# Altium schematic sheet [04] - USER IO AND LEDs.SchDoc("[04] - USER IO AND LEDs")
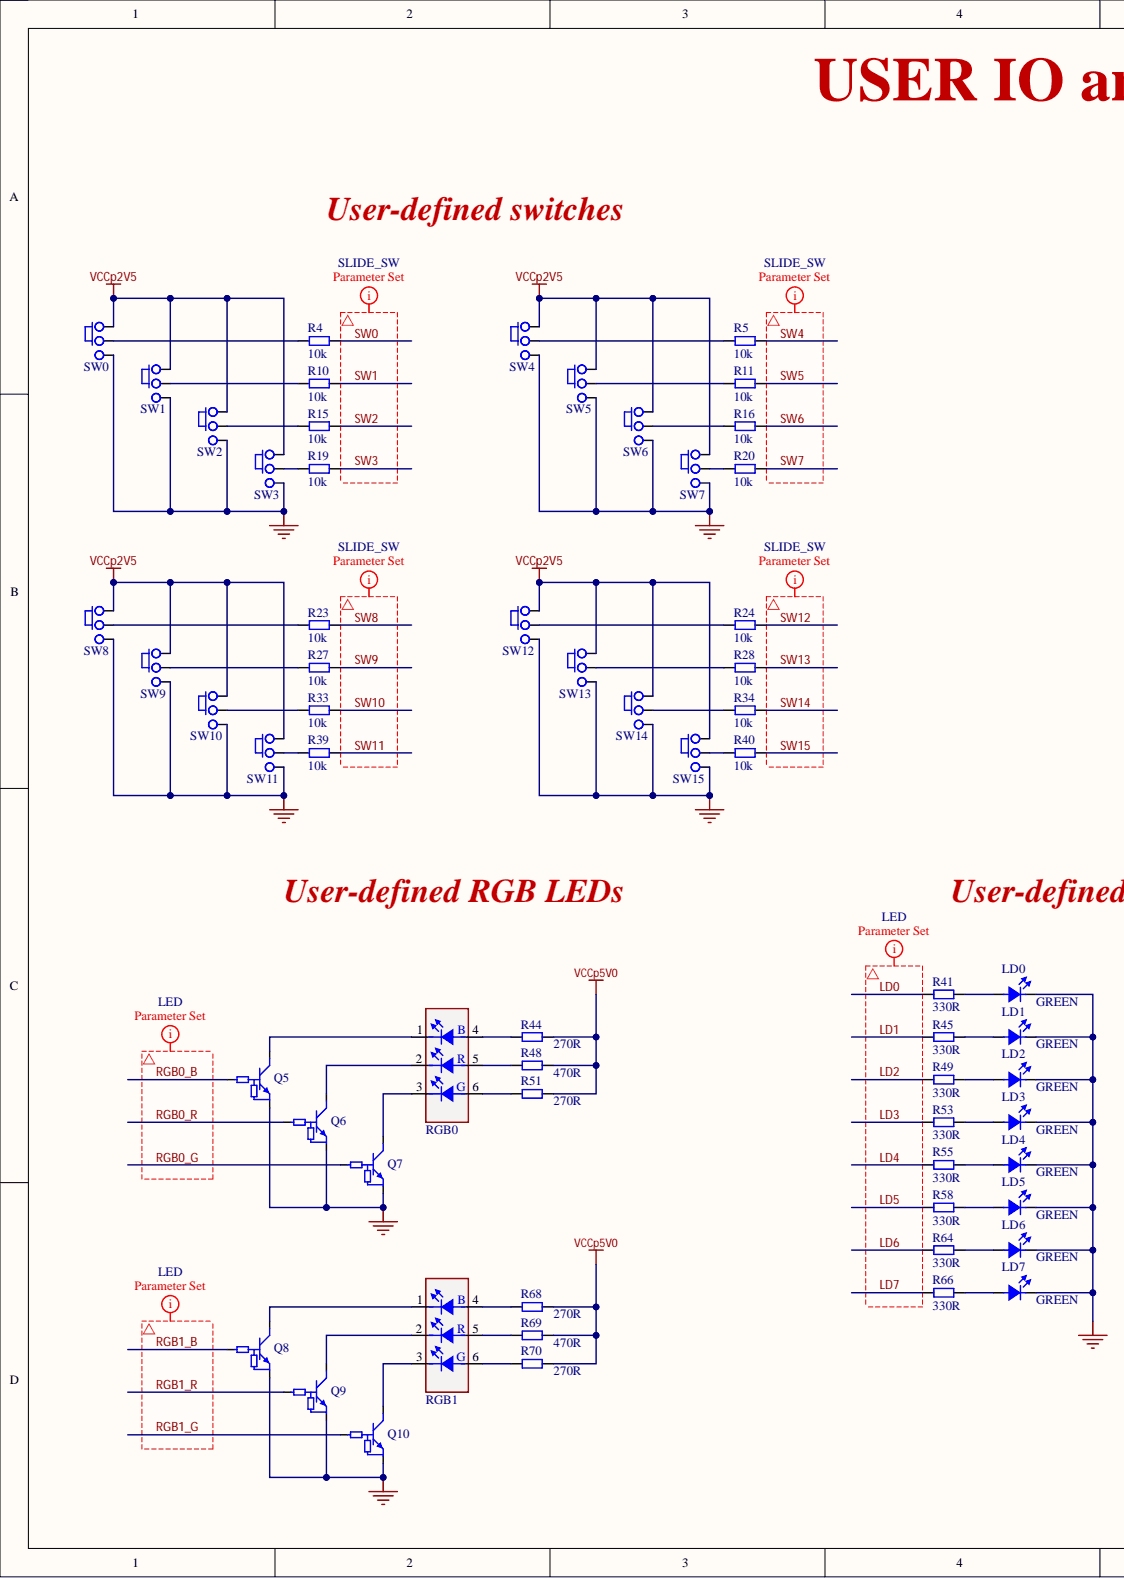
[diagram p0: [04] - USER IO AND LEDs.SchDoc, left]
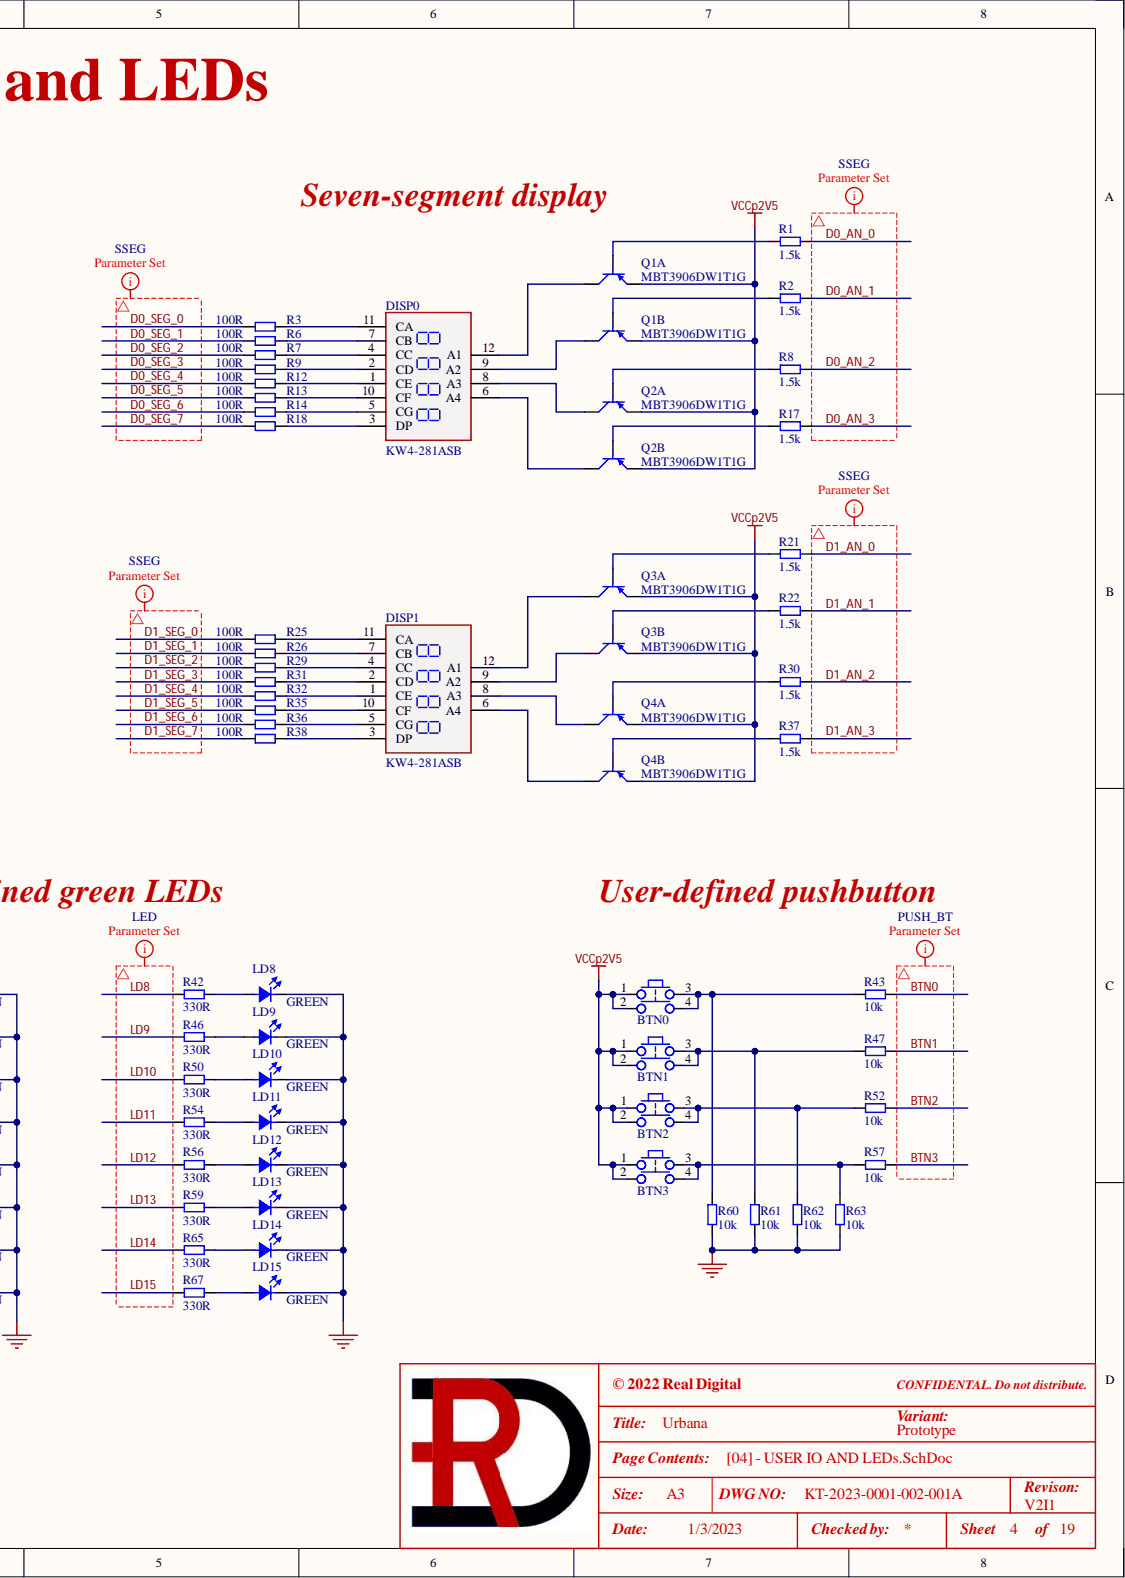
[diagram p1: [04] - USER IO AND LEDs.SchDoc, right]

## Parts
BTN0  PTA-142  [PTA-142]  (value not drawn)
BTN1  PTA-142  [PTA-142]  (value not drawn)
BTN2  PTA-142  [PTA-142]  (value not drawn)
BTN3  PTA-142  [PTA-142]  (value not drawn)
DISP0  KW4-281ASB  [KW4-281ASB]
DISP1  KW4-281ASB  [KW4-281ASB]
LD0  GREEN  [LD0603_Green]
LD1  GREEN  [LD0603_Green]
LD2  GREEN  [LD0603_Green]
LD3  GREEN  [LD0603_Green]
LD4  GREEN  [LD0603_Green]
LD5  GREEN  [LD0603_Green]
LD6  GREEN  [LD0603_Green]
LD7  GREEN  [LD0603_Green]
LD8  GREEN  [LD0603_Green]
LD9  GREEN  [LD0603_Green]
LD10  GREEN  [LD0603_Green]
LD11  GREEN  [LD0603_Green]
LD12  GREEN  [LD0603_Green]
LD13  GREEN  [LD0603_Green]
LD14  GREEN  [LD0603_Green]
LD15  GREEN  [LD0603_Green]
Q1  MBT3906DW1T1G  [SOT-363]  (parts A,B)
Q2  MBT3906DW1T1G  [SOT-363]  (parts A,B)
Q3  MBT3906DW1T1G  [SOT-363]  (parts A,B)
Q4  MBT3906DW1T1G  [SOT-363]  (parts A,B)
Q5  DTC043ZEBTL  [SC89-EMT3F]  (value not drawn)
Q6  DTC043ZEBTL  [SC89-EMT3F]  (value not drawn)
Q7  DTC043ZEBTL  [SC89-EMT3F]  (value not drawn)
Q8  DTC043ZEBTL  [SC89-EMT3F]  (value not drawn)
Q9  DTC043ZEBTL  [SC89-EMT3F]  (value not drawn)
Q10  DTC043ZEBTL  [SC89-EMT3F]  (value not drawn)
R1  1.5k  [R0402]
R2  1.5k  [R0402]
R3  100R  [R0402]
R4  10k  [R0402]
R5  10k  [R0402]
R6  100R  [R0402]
R7  100R  [R0402]
R8  1.5k  [R0402]
R9  100R  [R0402]
R10  10k  [R0402]
R11  10k  [R0402]
R12  100R  [R0402]
R13  100R  [R0402]
R14  100R  [R0402]
R15  10k  [R0402]
R16  10k  [R0402]
R17  1.5k  [R0402]
R18  100R  [R0402]
R19  10k  [R0402]
R20  10k  [R0402]
R21  1.5k  [R0402]
R22  1.5k  [R0402]
R23  10k  [R0402]
R24  10k  [R0402]
R25  100R  [R0402]
R26  100R  [R0402]
R27  10k  [R0402]
R28  10k  [R0402]
R29  100R  [R0402]
R30  1.5k  [R0402]
R31  100R  [R0402]
R32  100R  [R0402]
R33  10k  [R0402]
R34  10k  [R0402]
R35  100R  [R0402]
R36  100R  [R0402]
R37  1.5k  [R0402]
R38  100R  [R0402]
R39  10k  [R0402]
R40  10k  [R0402]
R41  330R  [R0402]
R42  330R  [R0402]
R43  10k  [R0402]
R44  270R  [R0402]
R45  330R  [R0402]
R46  330R  [R0402]
R47  10k  [R0402]
R48  470R  [R0402]
R49  330R  [R0402]
R50  330R  [R0402]
R51  270R  [R0402]
R52  10k  [R0402]
R53  330R  [R0402]
R54  330R  [R0402]
R55  330R  [R0402]
R56  330R  [R0402]
R57  10k  [R0402]
R58  330R  [R0402]
R59  330R  [R0402]
R60  10k  [R0402]
R61  10k  [R0402]
R62  10k  [R0402]
R63  10k  [R0402]
R64  330R  [R0402]
R65  330R  [R0402]
R66  330R  [R0402]
R67  330R  [R0402]
R68  270R  [R0402]
R69  470R  [R0402]
R70  270R  [R0402]
RGB0  VS NRD8  [LD_RGB_NRD8]  (value not drawn)
RGB1  VS NRD8  [LD_RGB_NRD8]  (value not drawn)
SW0  Switch  [SW-10SP001]  (value not drawn)
SW1  Switch  [SW-10SP001]  (value not drawn)
SW2  Switch  [SW-10SP001]  (value not drawn)
SW3  Switch  [SW-10SP001]  (value not drawn)
SW4  Switch  [SW-10SP001]  (value not drawn)
SW5  Switch  [SW-10SP001]  (value not drawn)
SW6  Switch  [SW-10SP001]  (value not drawn)
SW7  Switch  [SW-10SP001]  (value not drawn)
SW8  Switch  [SW-10SP001]  (value not drawn)
SW9  Switch  [SW-10SP001]  (value not drawn)
SW10  Switch  [SW-10SP001]  (value not drawn)
SW11  Switch  [SW-10SP001]  (value not drawn)
SW12  Switch  [SW-10SP001]  (value not drawn)
SW13  Switch  [SW-10SP001]  (value not drawn)
SW14  Switch  [SW-10SP001]  (value not drawn)
SW15  Switch  [SW-10SP001]  (value not drawn)

## Nets
GND: LD0.K LD1.K LD2.K LD3.K LD4.K LD5.K LD6.K LD7.K LD8.K LD9.K LD10.K LD11.K LD12.K LD13.K LD14.K LD15.K Q5.GND Q6.GND Q7.GND Q8.GND Q9.GND Q10.GND R60.1 R61.1 R62.1 R63.1 SW0.3 SW1.3 SW2.3 SW3.3 SW4.3 SW5.3 SW6.3 SW7.3 SW8.3 SW9.3 SW10.3 SW11.3 SW12.3 SW13.3 SW14.3 SW15.3
VCCp2V5: BTN0.1 BTN0.2 BTN1.1 BTN1.2 BTN2.1 BTN2.2 BTN3.1 BTN3.2 Q1.1 Q1.4 Q2.1 Q2.4 Q3.1 Q3.4 Q4.1 Q4.4 SW0.1 SW1.1 SW2.1 SW3.1 SW4.1 SW5.1 SW6.1 SW7.1 SW8.1 SW9.1 SW10.1 SW11.1 SW12.1 SW13.1 SW14.1 SW15.1
VCCp5V0: R44.2 R48.2 R51.2 R68.2 R69.2 R70.2
BTN0: R43.2
BTN1: R47.2
BTN2: R52.2
BTN3: R57.2
D0_AN_0: R1.2
D0_AN_1: R2.2
D0_AN_2: R8.2
D0_AN_3: R17.2
D0_SEG_0: R3.1
D0_SEG_1: R6.1
D0_SEG_2: R7.1
D0_SEG_3: R9.1
D0_SEG_4: R12.1
D0_SEG_5: R13.1
D0_SEG_6: R14.1
D0_SEG_7: R18.1
D1_AN_0: R21.2
D1_AN_1: R22.2
D1_AN_2: R30.2
D1_AN_3: R37.2
D1_SEG_0: R25.1
D1_SEG_1: R26.1
D1_SEG_2: R29.1
D1_SEG_3: R31.1
D1_SEG_4: R32.1
D1_SEG_5: R35.1
D1_SEG_6: R36.1
D1_SEG_7: R38.1
LD0: R41.1
LD1: R45.1
LD2: R49.1
LD3: R53.1
LD4: R55.1
LD5: R58.1
LD6: R64.1
LD7: R66.1
LD8: R42.1
LD9: R46.1
LD10: R50.1
LD11: R54.1
LD12: R56.1
LD13: R59.1
LD14: R65.1
LD15: R67.1
RGB0_B: Q5.IN
RGB0_G: Q7.IN
RGB0_R: Q6.IN
RGB1_B: Q8.IN
RGB1_G: Q10.IN
RGB1_R: Q9.IN
SW0: R4.2
SW1: R10.2
SW2: R15.2
SW3: R19.2
SW4: R5.2
SW5: R11.2
SW6: R16.2
SW7: R20.2
SW8: R23.2
SW9: R27.2
SW10: R33.2
SW11: R39.2
SW12: R24.2
SW13: R28.2
SW14: R34.2
SW15: R40.2
NetBTN0_3: BTN0.3 BTN0.4 R43.1 R60.2
NetBTN1_3: BTN1.3 BTN1.4 R47.1 R61.2
NetBTN2_3: BTN2.3 BTN2.4 R52.1 R62.2
NetBTN3_3: BTN3.3 BTN3.4 R57.1 R63.2
NetDISP0_1: DISP0.1(CE) R12.2
NetDISP0_2: DISP0.2(CD) R9.2
NetDISP0_3: DISP0.3(DP) R18.2
NetDISP0_4: DISP0.4(CC) R7.2
NetDISP0_5: DISP0.5(CG) R14.2
NetDISP0_6: DISP0.6(A4) Q2.6
NetDISP0_7: DISP0.7(CB) R6.2
NetDISP0_8: DISP0.8(A3) Q2.3
NetDISP0_9: DISP0.9(A2) Q1.6
NetDISP0_10: DISP0.10(CF) R13.2
NetDISP0_11: DISP0.11(CA) R3.2
NetDISP0_12: DISP0.12(A1) Q1.3
NetDISP1_1: DISP1.1(CE) R32.2
NetDISP1_2: DISP1.2(CD) R31.2
NetDISP1_3: DISP1.3(DP) R38.2
NetDISP1_4: DISP1.4(CC) R29.2
NetDISP1_5: DISP1.5(CG) R36.2
NetDISP1_6: DISP1.6(A4) Q4.6
NetDISP1_7: DISP1.7(CB) R26.2
NetDISP1_8: DISP1.8(A3) Q4.3
NetDISP1_9: DISP1.9(A2) Q3.6
NetDISP1_10: DISP1.10(CF) R35.2
NetDISP1_11: DISP1.11(CA) R25.2
NetDISP1_12: DISP1.12(A1) Q3.3
NetLD0_A: LD0.A R41.2
NetLD1_A: LD1.A R45.2
NetLD2_A: LD2.A R49.2
NetLD3_A: LD3.A R53.2
NetLD4_A: LD4.A R55.2
NetLD5_A: LD5.A R58.2
NetLD6_A: LD6.A R64.2
NetLD7_A: LD7.A R66.2
NetLD8_A: LD8.A R42.2
NetLD9_A: LD9.A R46.2
NetLD10_A: LD10.A R50.2
NetLD11_A: LD11.A R54.2
NetLD12_A: LD12.A R56.2
NetLD13_A: LD13.A R59.2
NetLD14_A: LD14.A R65.2
NetLD15_A: LD15.A R67.2
NetQ1_2: Q1.2 R2.1
NetQ1_5: Q1.5 R1.1
NetQ2_2: Q2.2 R17.1
NetQ2_5: Q2.5 R8.1
NetQ3_2: Q3.2 R22.1
NetQ3_5: Q3.5 R21.1
NetQ4_2: Q4.2 R37.1
NetQ4_5: Q4.5 R30.1
NetQ5_OUT: Q5.OUT RGB0.1
NetQ6_OUT: Q6.OUT RGB0.2
NetQ7_OUT: Q7.OUT RGB0.3
NetQ8_OUT: Q8.OUT RGB1.1
NetQ9_OUT: Q9.OUT RGB1.2
NetQ10_OUT: Q10.OUT RGB1.3
NetR4_1: R4.1 SW0.2
NetR5_1: R5.1 SW4.2
NetR10_1: R10.1 SW1.2
NetR11_1: R11.1 SW5.2
NetR15_1: R15.1 SW2.2
NetR16_1: R16.1 SW6.2
NetR19_1: R19.1 SW3.2
NetR20_1: R20.1 SW7.2
NetR23_1: R23.1 SW8.2
NetR24_1: R24.1 SW12.2
NetR27_1: R27.1 SW9.2
NetR28_1: R28.1 SW13.2
NetR33_1: R33.1 SW10.2
NetR34_1: R34.1 SW14.2
NetR39_1: R39.1 SW11.2
NetR40_1: R40.1 SW15.2
NetR44_1: R44.1 RGB0.4
NetR48_1: R48.1 RGB0.5
NetR51_1: R51.1 RGB0.6
NetR68_1: R68.1 RGB1.4
NetR69_1: R69.1 RGB1.5
NetR70_1: R70.1 RGB1.6

## Library data of the parts
BTN0-BTN3 = Tact Switch: SWITCH TACTILE SPST-NO, 4.50mm x 4.50mm; Manufacturer 1: Pacer Technology Co., Ltd; Manufacturer 2 Part Number: TSXH-2GL; .Checked_PCB: RV; C P/N: 153-0059; LoadStatus: Load; Category: Tactile Switches; Component Kind: Standard; Library Name: KT-RealDigital.IntLib; Manufacturer 1 Part Number: PTA-142; .Checked_SCH: RV; .Creator: RV
DISP0 DISP1 = KW4-281ASB: 4 Digit, 12 Pins, Common Anode , 7 Segment Display , Red; Manufacturer 1: Luckylight Electronics Co., Ltd; Manufacturer 2 Part Number: 2841-BSR; .Checked_PCB: AC; .Creator: RV; C P/N: 144-0013; LoadStatus: Load; Category: Display; Component Kind: Standard; Library Name: KT-RealDigital.IntLib; Manufacturer 1 Part Number: KW4-281ASB; Manufacturer 2: Houkem; .Checked_SCH: AC
LD0-LD15 = LED_GREEN: LED GREEN 0603; Manufacturer 1: Everlight Electronics CO., LTD; Manufacturer 1 Part Number: 19-21SYGC/S530-E4/TR8; .Checked_PCB: AC; .Creator: RV; C P/N: 121-0006; LoadStatus: Load; Category: Led; Component Kind: Standard; Library Name: KT-RealDigital.IntLib; .Checked_SCH: AC
Q1-Q4 = MBT3906DW1T1G: TRANS 2PNP 40V 0.2A SOT363; Manufacturer 1: ON Semiconductor; .Checked_PCB: AC; .Creator: RV; C P/N: 121-0032; LoadStatus: Load; Category: Transistors; Component Kind: Standard; Library Name: KT-RealDigital.IntLib; Manufacturer 1 Part Number: MBT3906DW1T1G; .Checked_SCH: AC
Q5-Q10 = DTC043ZEBTL: TRANS PREBIAS NPN 50V EMT3F; Manufacturer 1: Rohm Semiconductor; .Checked_PCB: RV; LoadStatus: Load; Category: BJTs; Component Kind: Standard; Library Name: KT-RealDigital.IntLib; Manufacturer 1 Part Number: DTC043ZEBTL; .Checked_SCH: RV; .Creator: RV
R1 R2 R8 R17 R21 R22 R30 R37 = RC0402FR-071K5L: RES 1.5K OHM 1% 1/16W 0402; Manufacturer 1 Part Number: YAGEO; .Checked_PCB: RV; LoadStatus: Load; Category: Resistors; Component Kind: Standard; Library Name: KT-RealDigital.IntLib; Manufacturer 1: RC0402FR-071K5L; Tolerance: 1%; .Checked_SCH: RV; .Creator: RV
R3 R6 R7 R9 R12-R14 R18 R25 R26 R29 R31 R32 R35 R36 R38 = RC0402JR-07100RL: RES SMD 100 OHM 5% 1/16W 0402; Manufacturer 1: Yageo; .Checked_PCB: AC; .Creator: RV; C P/N: 101-0035; LoadStatus: Load; Category: Resistors; Component Kind: Standard; Library Name: KT-RealDigital.IntLib; Manufacturer 1 Part Number: RC0402JR-07100RL; Tolerance: 5%; .Checked_SCH: AC
R4 R5 R10 R11 R15 R16 R19 R20 R23 R24 R27 R28 R33 R34 R39 R40 R43 R47 R52 R57 R60-R63 = RC0402JR-0710KL: RES SMD 10K OHM 5% 1/16W 0402; Manufacturer 1: Yageo; .Checked_PCB: AC; .Creator: RV; C P/N: 101-0047; LoadStatus: Load; Category: Resistors; Component Kind: Standard; Library Name: KT-RealDigital.IntLib; Manufacturer 1 Part Number: RC0402JR-0710KL; Tolerance: 5%; .Checked_SCH: AC
R41 R42 R45 R46 R49 R50 R53-R56 R58 R59 R64-R67 = RC0402JR-07330RL: RES SMD 330 OHM 5% 1/16W 0402; Manufacturer 1: Yageo; .Checked_PCB: AC; .Creator: RV; C P/N: 101-0112; LoadStatus: Load; Category: Resistors; Component Kind: Standard; Library Name: KT-RealDigital.IntLib; Manufacturer 1 Part Number: RC0402JR-07330RL; Tolerance: 5%; .Checked_SCH: AC
R44 R51 R68 R70 = RC0402FR-07270RL: RES SMD 270 OHM 1% 1/16W 0402; Manufacturer 1: Yageo; .Checked_PCB: RV; LoadStatus: Load; Category: Resistors; Component Kind: Standard; Library Name: KT-RealDigital.IntLib; Manufacturer 1 Part Number: RC0402FR-07270RL; Tolerance: 1%; .Checked_SCH: RV; .Creator: RV
R48 R69 = RC0402JR-07470RL: RES SMD 470 OHM 5% 1/16W 0402; Manufacturer 1: Yageo; .Checked_PCB: AC; .Creator: RV; C P/N: 101-0052; LoadStatus: Load; Category: Resistors; Component Kind: Standard; Library Name: KT-RealDigital.IntLib; Manufacturer 1 Part Number: RC0402JR-07470RL; Tolerance: 5%; .Checked_SCH: AC
RGB0 RGB1 = LED_RGB: LED RGB; Manufacturer 1: Victory Electronics; .Checked_PCB: RV; C P/N: 121-0264; LoadStatus: Load; Category: Led; Component Kind: Standard; Library Name: KT-RealDigital.IntLib; Manufacturer 1 Part Number: VS NRD8; .Checked_SCH: RV; .Creator: RV
SW0-SW15 = Slide_Switch: Slide Switch, SPDT, Thru Hole,; Manufacturer 1: Mountain Switch; Manufacturer 1 Part Number: 10SP001; Manufacturer 2 Part Number: BSL-SS12F56-V4; .Checked_PCB: AC; .Creator: RV; C P/N: 153-0001; LoadStatus: Load; Category: Switches; Component Kind: Standard; Library Name: KT-RealDigital.IntLib; .Checked_SCH: AC
